# Altium schematic sheet Microphone-Boards_V1.0_GMA4030H11.SchDoc
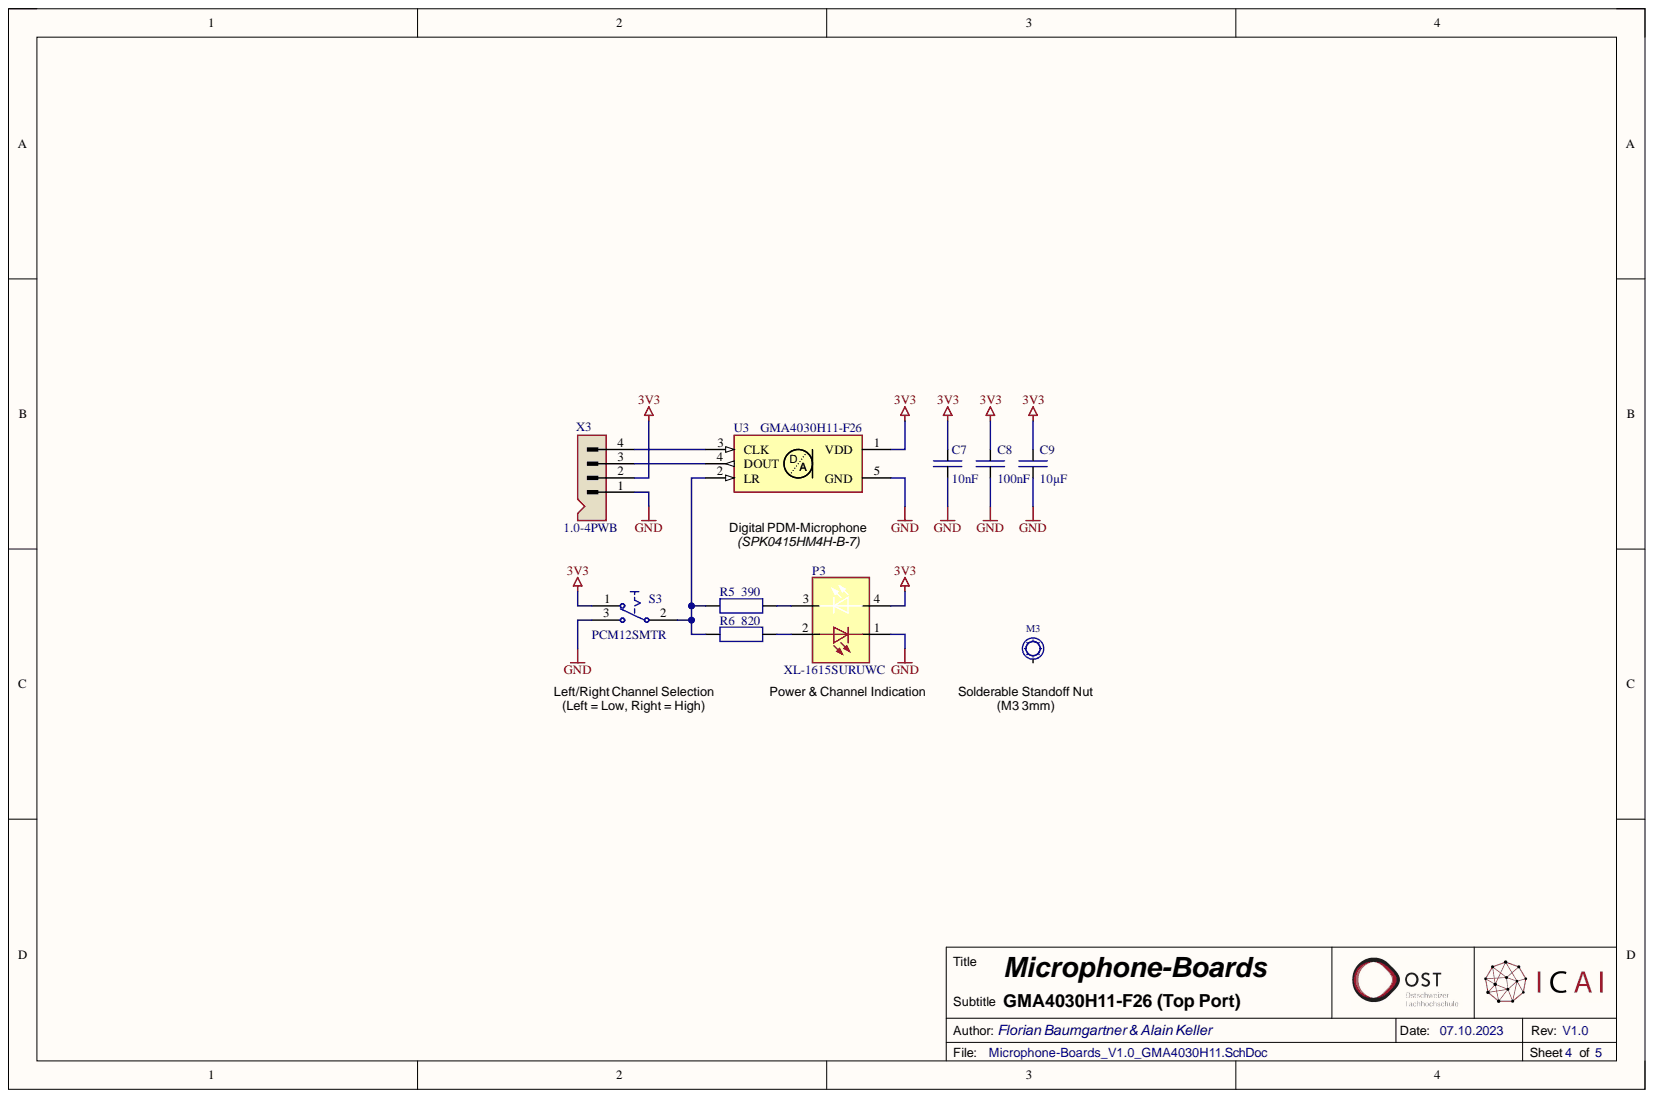
[diagram p0: Microphone-Boards_V1.0_GMA4030H11.SchDoc]

## Parts
C7  10nF  [SMD Keramikkondensator 0603]
C8  100nF  [SMD Keramikkondensator 0603]
C9  10µF  [SMD Keramikkondensator 1206]
M3  SMTSO-M3-3ET  [SMD Solder-Nut (M3 3mm)]  (value not drawn)
P3  XL-1615SURUWC  [SMD LED 1210]
R5  390  [SMD Widerstand 0603]
R6  820  [SMD Widerstand 0603]
S3  PCM12SMTR  [SMD Switch SPDT (PCM12SMTR)]
U3  GMA4030H11-F26  [SMD Microphone (MP34DT06JTR)]
X3  1.0-4PWB  [SMD Connector (SM04B-SRSS-TB)]

## Nets
3V3: C7.2 C8.2 C9.2 P3.4 S3.1 U3.1(VDD) X3.2
GND: C7.1 C8.1 C9.1 P3.1 S3.3 U3.5(GND) X3.1
NetP3_2: P3.2 R6.2
NetP3_3: P3.3 R5.2
NetR5_1: R5.1 R6.1 S3.2 U3.2(LR)
NetU3_3: U3.3(CLK) X3.4
NetU3_4: U3.4(DOUT) X3.3

Not connected: M3 1

## Library data of the parts
C7 = C-CAPACITOR: CAP-MK; Component Description: Multilayer Ceramic Capacitors; Component Value: 10nF 50V; Component Kind: Standard; Digikey: 445-5662-1-ND; Farnell: 2346966; JLC-Parts: C57112; Library Name: AudioAmp.SCHLIB; Mouser: 77-VJ0603V103ZXAPBC; Unit Price: 0.01
C8 = E6 - 100nF: SMD Ceramic Capacitor E6; Component Description: Multilayer Ceramic Capacitors MLCC; Component Value: 100nF 50V; Component Kind: Standard; ComponentLink1Description: Datasheet; ComponentLink1URL: https://datasheet.lcsc.com/lcsc/1809301912_YAGEO-CC0603KRX7R9BB104_C14663.pdf; Digikey: 445-6899-1-ND; Farnell: 2210822; JLC-Parts: C14663; Library Name: Ceramic Capacitors-E6.SchLib; Mouser: 810-CGA2B3X7R1H104K; Unit Price: 0.01
C9 = E6 - 10uF: SMD Ceramic Capacitor E6; Component Description: Multilayer Ceramic Capacitors MLCC; Component Value: 10µF 50V; Component Kind: Standard; ComponentLink1Description: Datasheet; ComponentLink1URL: https://datasheet.lcsc.com/lcsc/1810191219_Samsung-Electro-Mechanics-CL10A106KP8NNNC_C19702.pdf; Digikey: 587-3258-1-ND; Farnell: 2113070; JLC-Parts: C13585; Library Name: Ceramic Capacitors-E6.SchLib; Mouser: 963-LMK107BBJ106MALT; Unit Price: 0.01
M3 = M-Spacer_M3_3mm: Solderable Standoff Nut; Component Value: M3 3mm; Component Description: Solderable Standoff Nut; Component Kind: Standard; ComponentLink1Description: Datasheet; ComponentLink1URL: https://datasheet.lcsc.com/lcsc/2112061830_Sinhoo-SMTSO3030CTJ_C2915308.pdf; JLC-Parts: C2915308; Mouser: 153-SMTSO-M3-3ET; Unit Price: 0.05; Library Name: Velotour_2022.SCHLIB
P3 = P-XL-1615SURUWC: LED Red/White; Stückpreis: 0.02; Bauteilwert: XL-1615SURUWC; Beschreibung: LED Red/White; Component Kind: Standard; ComponentLink1Description: Datasheet; ComponentLink1URL: https://datasheet.lcsc.com/lcsc/2211030000_XINGLIGHT-XL-1615SURUWC_C965837.pdf; JLC-Parts: C965837; Library Name: Microphone-Boards.SCHLIB
R5 = R-RESISTOR: SMD-Widerstand 1/4W; Component Description: Thick Film Chip Resistor; Component Value: 390 (75V, 100mW, 1%); Component Kind: Standard; Digikey: P390HCT-ND; Farnell: 2303111; JLC-Parts: C23151; Mouser: 667-ERJ-3EKF3900V; Unit Price: 0.06; Library Name: Backplane_1.SCHLIB
R6 = R-RESISTOR: SMD-Widerstand 1/4W; Component Description: Thick Film Chip Resistor; Component Value: 820 (75V, 100mW, 1%); Component Kind: Standard; Digikey: P820HCT-ND; Farnell: 2303136; JLC-Parts: C23253; Mouser: 667-ERJ-3EKF8200V; Unit Price: 0.06; Library Name: Backplane_1.SCHLIB
S3 = S-PCM12SMTR: Miniature Slide Switch; aliExpress: 33002042977; Bauteilwert: PCM12SMTR (SPDT); Stückpreis: 0.77; Beschreibung: Miniature Slide Switch; Component Kind: Standard; Digikey: 401-2016-1-ND; Farnell: 9575146; Library Name: Custom ST-Link.SchLib; Mouser: 611-PCM12SMTR
U3 = U-GMA4030H11-F26: Digital PDM MEMS Microphone (Top Port); Component Description: Digital PDM MEMS Microphone (Top Port); Component Kind: Standard; Component Value: GMA4030H11-F26; ComponentLink1Description: Datasheet; ComponentLink1URL: https://datasheet.lcsc.com/lcsc/2005071903_INGHAi-GMA4030H11-F26_C498193.pdf; Digikey: 423-1210-1-ND; JLC-Parts: C498193; Library Name: Microphone-Boards.SCHLIB; Mouser: 721-SPK0415HM4H-B-7; Unit Price: 1.11
X3 = X-Stiftleiste (4-Fach): Wire To Board Connector (1x4P 1.0mm); Component Description: Wire To Board Connector (1x4P 1.0mm); Component Kind: Standard; Component Value: 1.0-4PWB; Digikey: 455-SM04B-SRSS-TBCT-ND; Farnell: 1830839; JLC-Parts: C2905006; Unit Price: 0.03; Library Name: Audio-Beamformer.SCHLIB
